# Altium schematic sheet TempPress.SchDoc
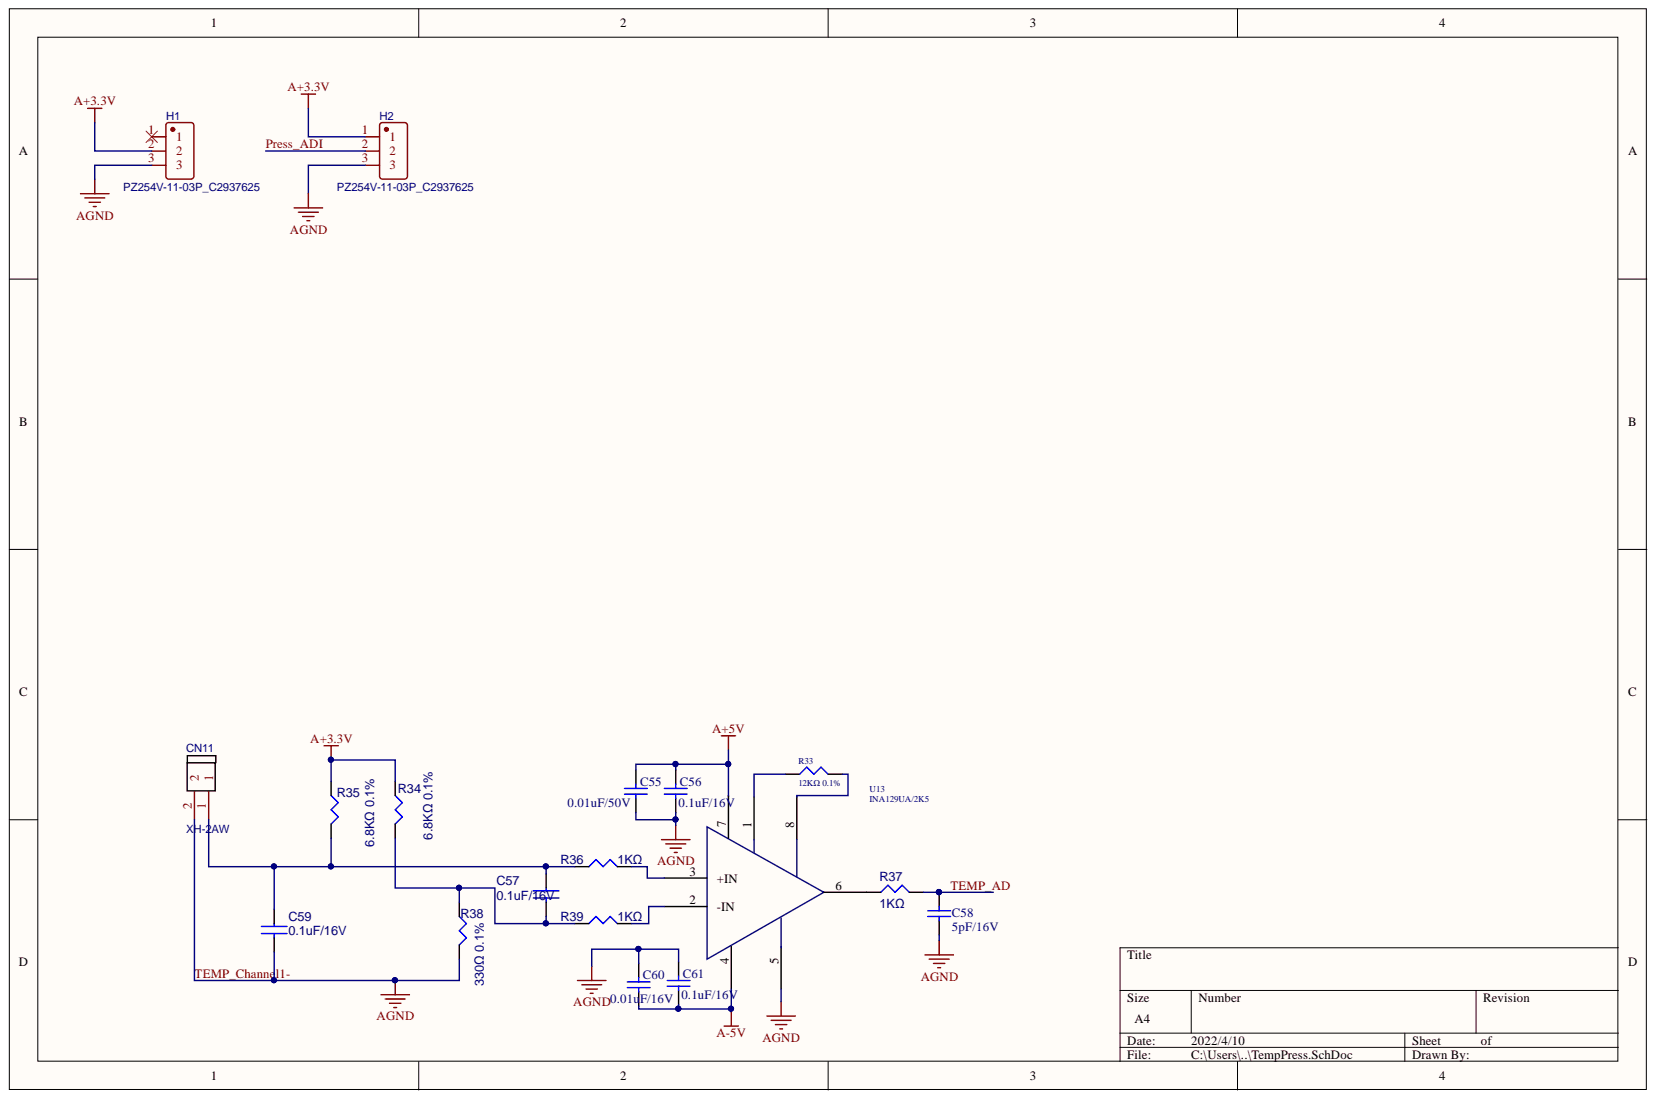
[diagram p0: TempPress.SchDoc]

## Parts
C55  0.01uF/50V  [C0603]
C56  0.1uF/16V  [C0603]
C57  0.1uF/16V  [C0603]
C58  5pF/16V  [C0603]
C59  0.1uF/16V  [C0603]
C60  0.01uF/16V  [C0603]
C61  0.1uF/16V  [C0603]
CN11  XH-2AW  [XH-2P]
H1  PZ254V-11-03P_C2937625  [2.54*3]
H2  PZ254V-11-03P_C2937625  [2.54*3]
R33  12KΩ 0.1%  [R0603]
R34  6.8KΩ 0.1%  [R0603]
R35  6.8KΩ 0.1%  [R0603]
R36  1KΩ  [R0603]
R37  1KΩ  [R0603]
R38  330Ω 0.1%  [R0603]
R39  1KΩ  [R0603]
U13  INA129UA/2K5  [SOIC-8]

## Nets
A+3.3V: H1.2 H2.1 R34.1 R35.1
A+5V: C55.2 C56.2 U13.7
A-5V: C60.1 C61.1 U13.4
Press_ADI: H2.2
TEMP_AD: C58.2 R37.2
TEMP_Channel1-: C55.1 C56.1 C58.1 C59.2 C60.2 C61.2 CN11.2 H1.3 H2.3 R38.2 U13.5
NetC57_1: C57.1 C59.1 CN11.1 R35.2 R36.1
NetC57_2: C57.2 R34.2 R38.1 R39.1
NetR33_1: R33.1 U13.8
NetR33_2: R33.2 U13.1
NetR36_2: R36.2 U13.3(+IN)
NetR37_1: R37.1 U13.6
NetR39_2: R39.2 U13.2(-IN)

Not connected: H1 1

## Library data of the parts
C55 C56 C58 C60 C61 = 0402 10nF (103) 10% 50V: 贴片电容; Component Kind: Standard; ComponentLink1Description: 供应商链接; ComponentLink1URL: http://www.szlcsc.com/product/details_15869.html; Library Name: Cap.SchLib; Package: 0402; Supplier: LC; SuppliersPartNumber: C15195
C57 C59 = CAP NP 0805: Component Kind: Standard; Library Name: C-LifeReal.SchLib
CN11 = XH-2AW: BOM_Manufacturer: BOOMELE; BOM_JLCPCB Part Class: Extended Part; BOM_Manufacturer Part: XH-2AW; BOM_Supplier: LCSC; BOM_Supplier Part: C33132; Component Kind: Standard; spicePre: C; spiceSymbolName: XH-2AW
H1 H2 = PZ254V-11-03P_C2937625: BOM_Manufacturer: XFCN(台湾兴飞); Contributor: LCSC; BOM_JLCPCB Part Class: Extended Part; BOM_Manufacturer Part: PZ254V-11-03P; BOM_Supplier: LCSC; BOM_Supplier Part: C2937625; Component Kind: Standard; spicePre: H; spiceSymbolName: PZ254V-11-03P_C2937625
R33 = RES AXIAL0.3: Component Kind: Standard; Library Name: C-LifeReal.SchLib
R34-R39 = RES 0805: Component Kind: Standard; Library Name: C-LifeReal.SchLib
U13 = AD620: Component Kind: Standard; Library Name: Integrated_Library1.IntLib
